# Altium schematic sheet 02_ADIS16470_IMU.SchDoc(02_ADIS16470_IMU)
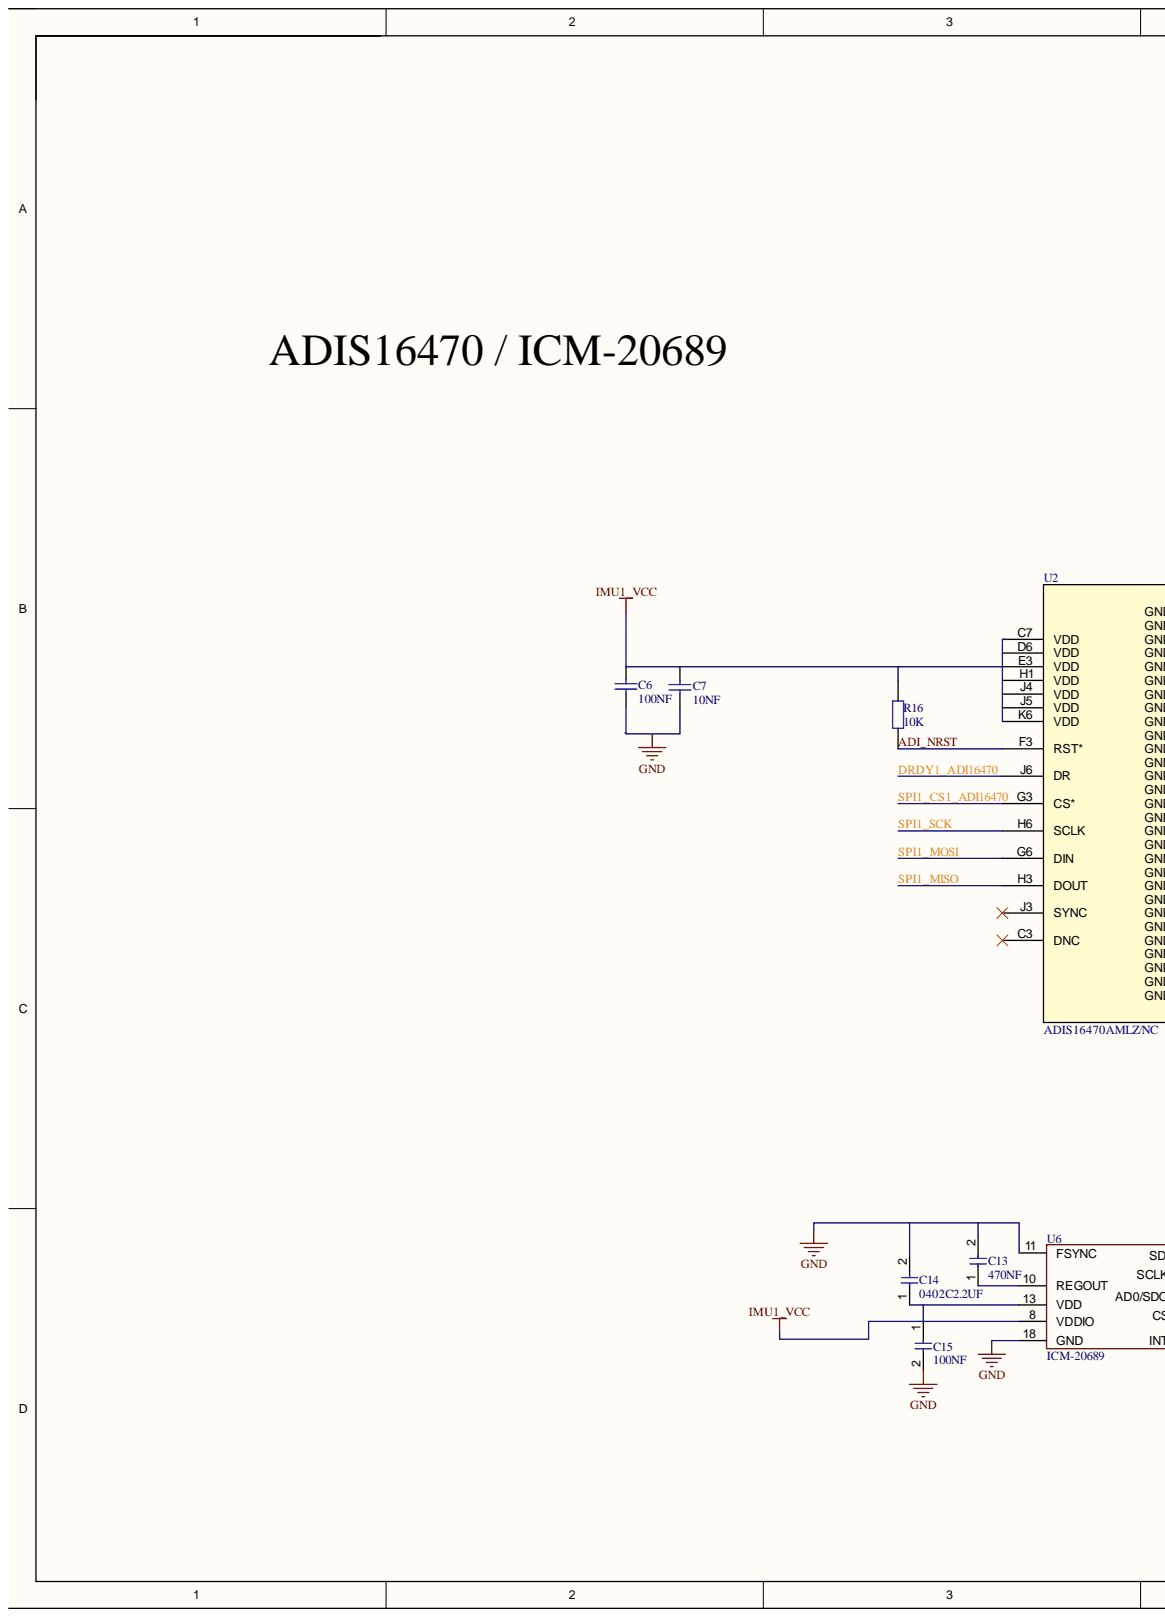
[diagram p0: 02_ADIS16470_IMU.SchDoc, left]
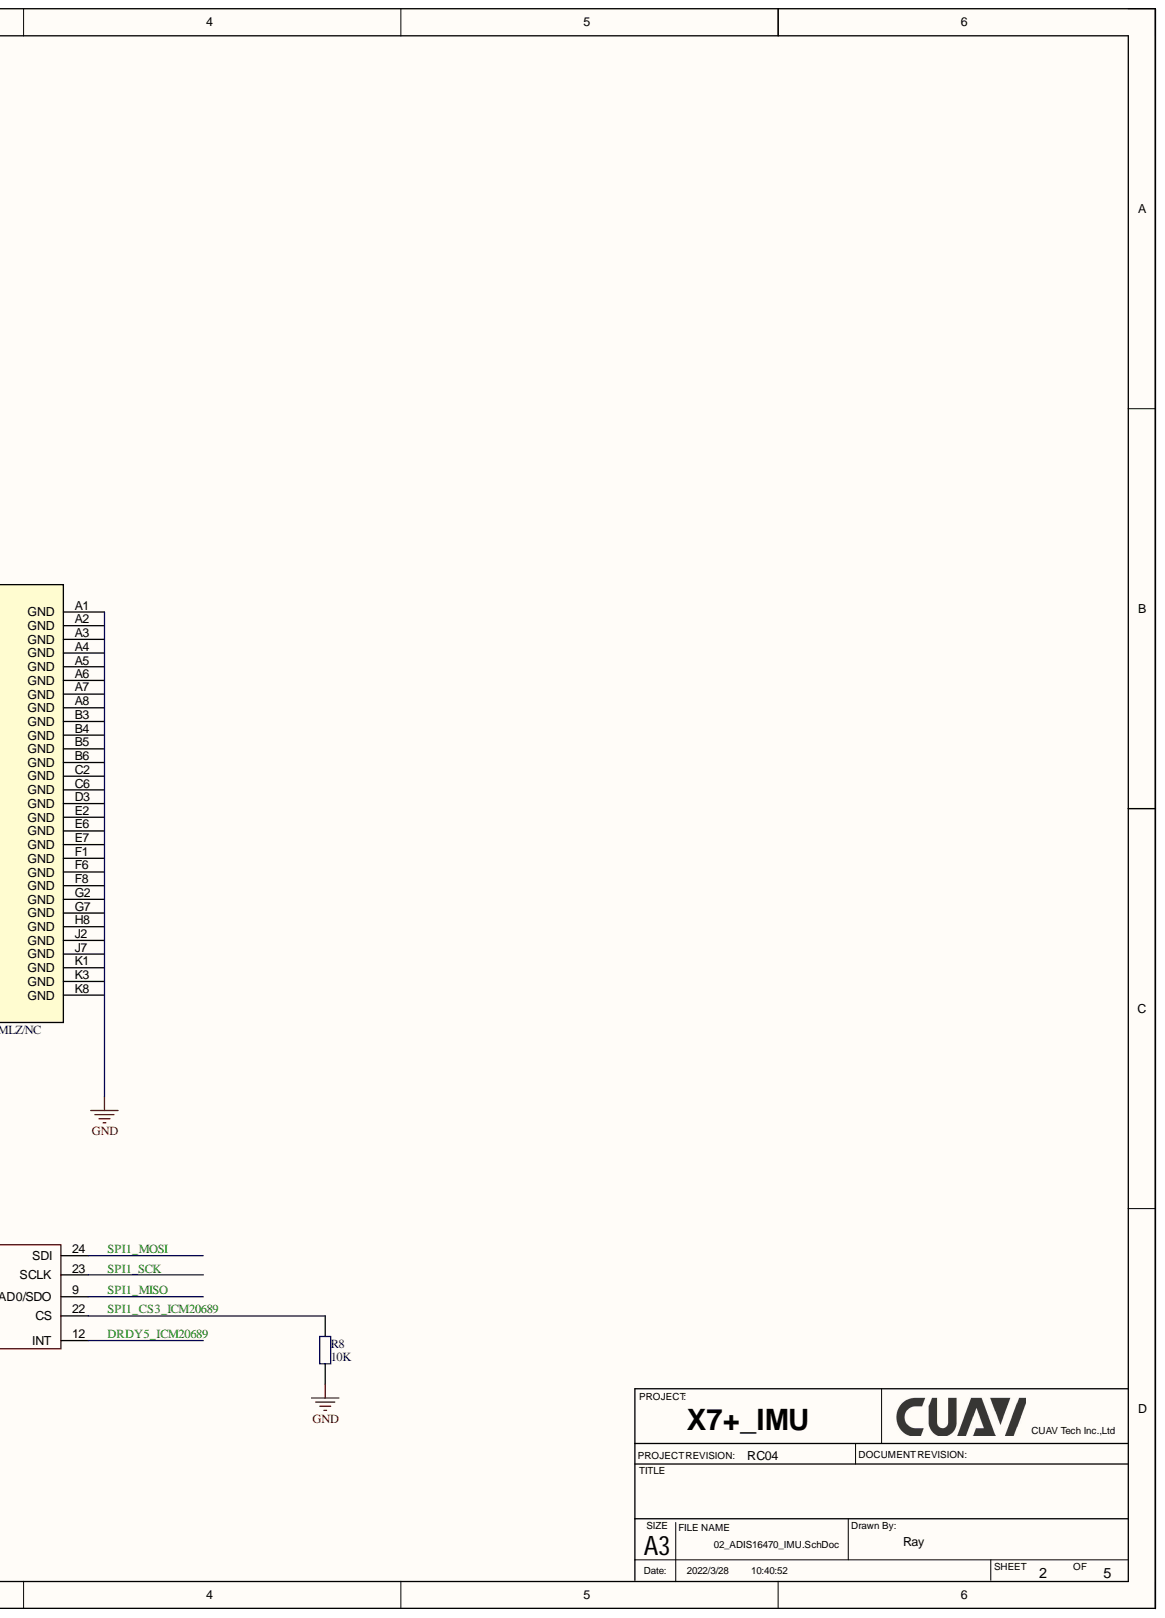
[diagram p1: 02_ADIS16470_IMU.SchDoc, right]

## Parts
C6  100NF  [0402CAP]
C7  10NF  [0402CAP]
C13  470NF  [0402C]
C14  0402C2.2UF  [0402C]
C15  100NF  [0402C]
R8  10K  [0402R]
R16  10K  [0402R]
U2  ADIS16470AMLZ/NC  [ML-44-1]
U6  ICM-20689  [QFN24]

## Nets
GND: C6.2 C7.2 C13.2 C14.2 C15.2 R8.1 U2.A1(GND) U2.A2(GND) U2.A3(GND) U2.A4(GND) U2.A5(GND) U2.A6(GND) U2.A7(GND) U2.A8(GND) U2.B3(GND) U2.B4(GND) U2.B5(GND) U2.B6(GND) U2.C2(GND) U2.C6(GND) U2.D3(GND) U2.E2(GND) U2.E6(GND) U2.E7(GND) U2.F1(GND) U2.F6(GND) U2.F8(GND) U2.G2(GND) U2.G7(GND) U2.H8(GND) U2.J2(GND) U2.J7(GND) U2.K1(GND) U2.K3(GND) U2.K8(GND) U6.11(FSYNC) U6.18(GND)
IMU1_VCC: C6.1 C7.1 C14.1 C15.1 R16.2 U2.C7(VDD) U2.D6(VDD) U2.E3(VDD) U2.H1(VDD) U2.J4(VDD) U2.J5(VDD) U2.K6(VDD) U6.8(VDDIO) U6.13(VDD)
ADI_NRST: R16.1 U2.F3(RST*)
DRDY1_ADI16470: U2.J6(DR)
DRDY5_ICM20689: U6.12(INT)
SPI1_CS1_ADI16470: U2.G3(CS*)
SPI1_CS3_ICM20689: R8.2 U6.22(CS)
SPI1_MISO: U2.H3(DOUT) U6.9(AD0/SDO)
SPI1_MOSI: U2.G6(DIN) U6.24(SDI)
SPI1_SCK: U2.H6(SCLK) U6.23(SCLK)
NetC13_1: C13.1 U6.10(REGOUT)

Not connected: U2 C3(DNC) J3(SYNC)

## Library data of the parts
C6 = Cap: 0402 100NF 50V 10%; Component Kind: Standard; Library Name: Miscellaneous Devices.IntLib; Signal Integrity: Cap; Simulation: CAP
C7 = Cap: Component Kind: Standard; Library Name: Miscellaneous Devices.IntLib; Signal Integrity: Cap; Simulation: CAP
C13 = 0402C2.2UF: 0402 470NF 50V 10%; Component Kind: Standard; Library Name: CUAV.SchLib
C14 = 0402C2.2UF: 0402 2.2UF 16V 10%; Component Kind: Standard; Library Name: CUAV.SchLib
C15 = 0402C220NF: 0402 100NF 10% 50V; Component Kind: Standard; Library Name: CUAV.SchLib
R8 R16 = 0402R0R: 0402R10K 1%; Component Kind: Standard; Library Name: CUAV.SchLib
U2 = ADIS16470AMLZ: Component Kind: Standard; Library Name: CUAV.SchLib
U6 = ICM-20689: Component Kind: Standard; Library Name: CUAV.SchLib
